# Altium schematic sheet B_Microchip.SchDoc
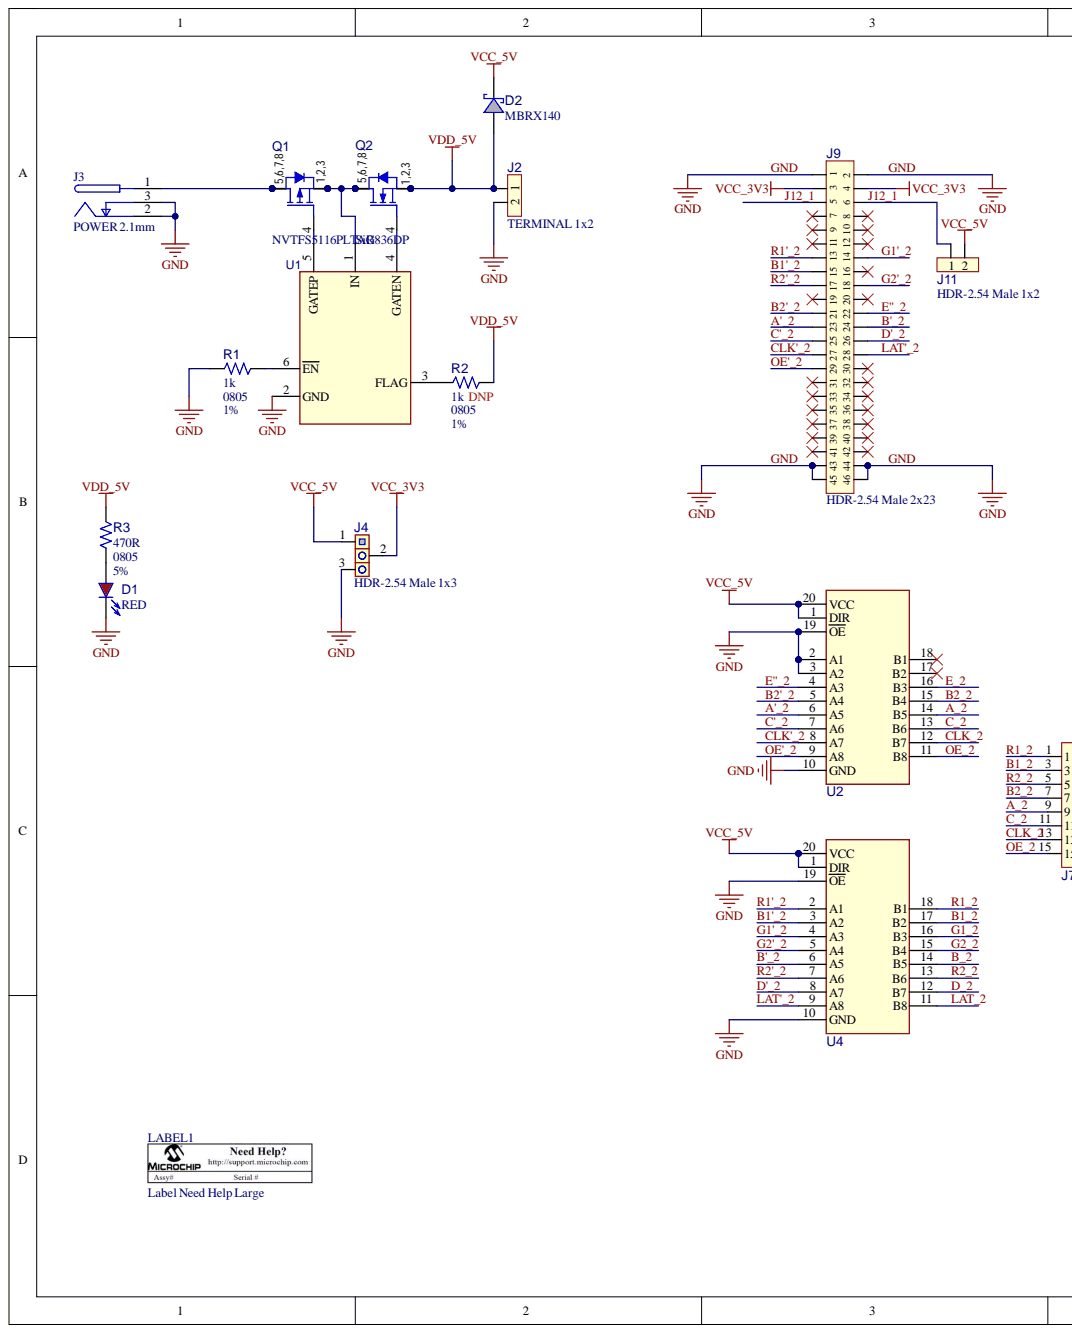
[diagram p0: B_Microchip.SchDoc, left]
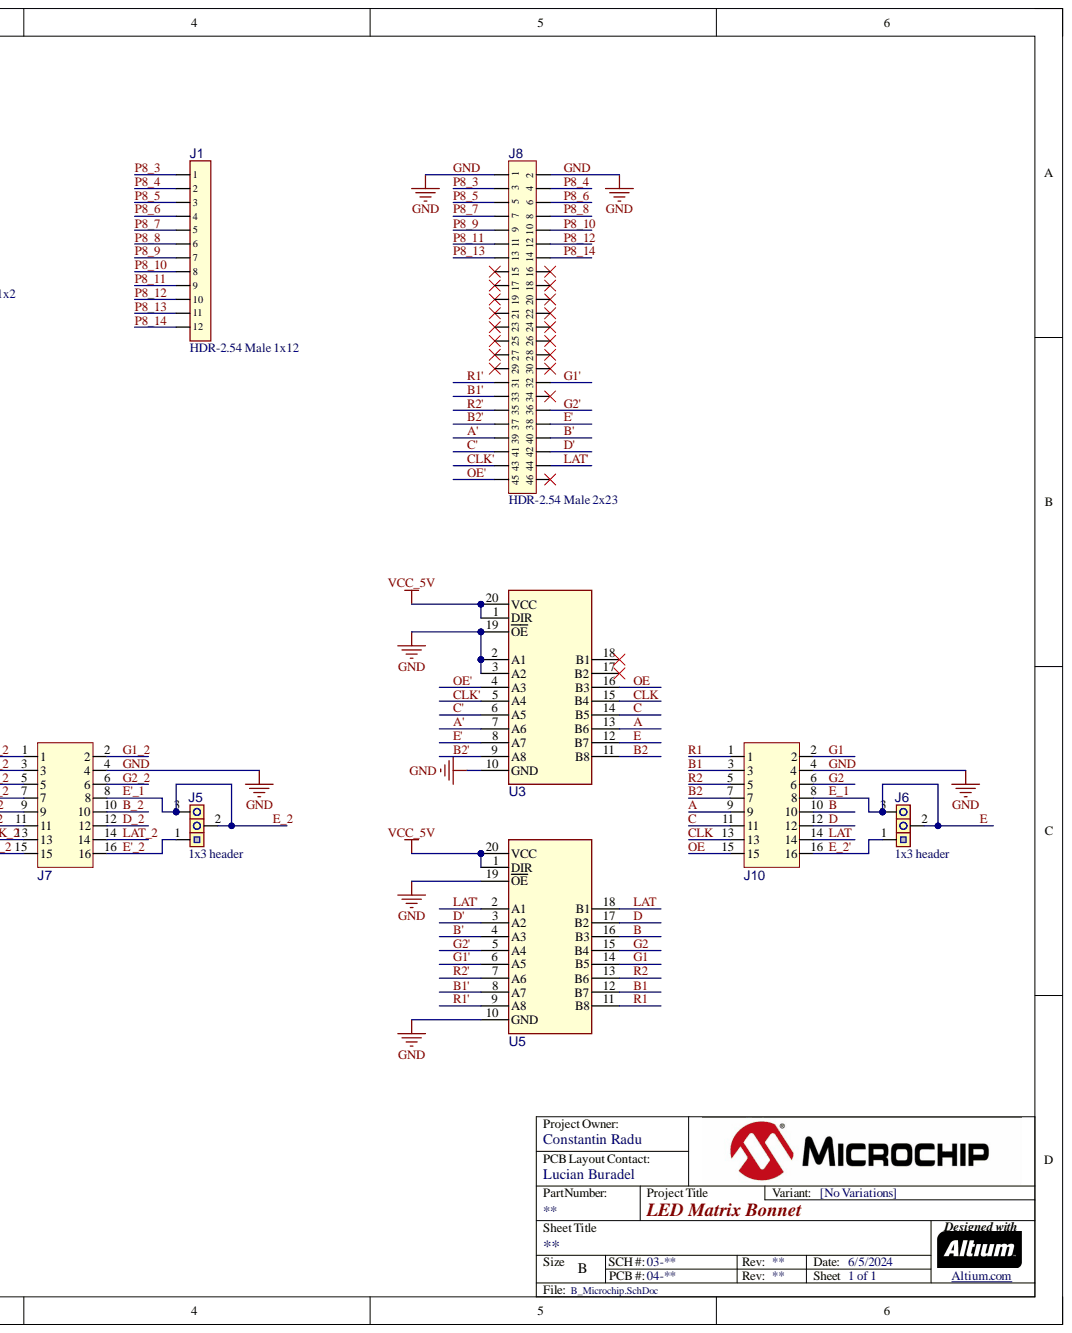
[diagram p1: B_Microchip.SchDoc, right]

## Parts
D1  value RED  Package: 0805  [LED_0805_Red]  (hidden comment: LED_red)
D2  value MBRX140  [SOD-123]  (hidden comment: Diode Schottky)
J1  value HDR-2.54 Male 1x12  [HDR_M_2.54_1x12]  (hidden comment: Header 1x12)
J2  value TERMINAL 1x2  [CON_TERMINAL_5_1x2_TH_RA_PHO_1935161]  (hidden comment: Header 1x2)
J3  value POWER 2.1mm  [CON_JACK_POWER_TH_RA_CUI_PJ-002]  (hidden comment: CON JACK 2C 3P SPST)
J4  value HDR-2.54 Male 1x3  [HDR_M_2.54_1x3]  (hidden comment: Header 1x3)
J5  value 1x3 header  [HDR_M_2.54_1x3]  (hidden comment: Header 1x3)
J6  value 1x3 header  [HDR_M_2.54_1x3]  (hidden comment: Header 1x3)
J7  Header 2x8  [HDR_M_2.54_2X8_S_TH_V_SAM_TSS-108-01-G-D]  (value not drawn)
J8  value HDR-2.54 Male 2x23  [HDR_M_2.54_2x23]  (hidden comment: Header 2x23)
J9  value HDR-2.54 Male 2x23  [HDR_M_2.54_2x23]  (hidden comment: Header 2x23)
J10  Header 2x8  [HDR_M_2.54_2X8_S_TH_V_SAM_TSS-108-01-G-D]  (value not drawn)
J11  value HDR-2.54 Male 1x2  [HDR_M_2.54_1x2]  (hidden comment: Header 1x2)
LABEL1  value Label Need Help Large  Category: Label  Type: Large  [LABEL_Need_Help_Large]  (hidden comment: LABEL_Need_Help_Large)
Q1  value NVTFS5116PLTAG  Manufacturer Part Number 1: NVTFS5116PLTAG  [WDFN-8_3.3x3.3]  (hidden comment: Transistor MOS-P SSSGDDDD)
Q2  value SiR836DP  [DFN3X3A_8L_NEP_P_AOS]  (hidden comment: Transistor MOS-N SSSGDDDD)
R1  value 1k  Package: 0805  Tolerance: 1%  [RES_C_0805]  (hidden comment: Resistor)
R2  value 1k  Package: 0805  Populated: DNP  [RES_C_0805]  (hidden comment: Resistor)
R3  value 470R  Package: 0805  Tolerance: 5%  [RES_C_0805]  (hidden comment: Resistor)
U1  MAX4864 SOT-23-6  [SOT-23-6]  (value not drawn)
U2  74AHCT245 TSSOP-20  [TSSOP-20]  (value not drawn)
U3  74AHCT245 TSSOP-20  [TSSOP-20]  (value not drawn)
U4  74AHCT245 TSSOP-20  [TSSOP-20]  (value not drawn)
U5  74AHCT245 TSSOP-20  [TSSOP-20]  (value not drawn)

## Nets
VCC_3V3: J4.2 J9.3 J9.4
VCC_5V: D2.2 J4.1 J11.2 U2.1(DIR) U2.20(VCC) U3.1(DIR) U3.20(VCC) U4.1(DIR) U4.20(VCC) U5.1(DIR) U5.20(VCC)
VDD_5V: D2.1 J2.1 Q2.1 Q2.2 Q2.3 R2.2 R3.2
A: J10.9 U3.13(B6)
A': J8.39 U3.7(A6)
A'_2: J9.23 U2.6(A5)
A_2: J7.9 U2.14(B5)
B: J10.10 U5.16(B3)
B1: J10.3 U5.12(B7)
B1': J8.33 U5.8(A7)
B1'_2: J9.15 U4.3(A2)
B1_2: J7.3 U4.17(B2)
B2: J10.7 U3.11(B8)
B2': J8.37 U3.9(A8)
B2'_2: J9.21 U2.5(A4)
B2_2: J7.7 U2.15(B4)
B': J8.40 U5.4(A3)
B'_2: J9.24 U4.6(A5)
B_2: J7.10 U4.14(B5)
C: J10.11 U3.14(B5)
C': J8.41 U3.6(A5)
C'_2: J9.25 U2.7(A6)
C_2: J7.11 U2.13(B6)
CLK: J10.13 U3.15(B4)
CLK': J8.43 U3.5(A4)
CLK'_2: J9.27 U2.8(A7)
CLK_2: J7.13 U2.12(B7)
D: J10.12 U5.17(B2)
D': J8.42 U5.3(A2)
D'_2: J9.26 U4.8(A7)
D_2: J7.12 U4.12(B7)
E: J6.2 J6.3 J10.8 U3.12(B7)
E': J8.38 U3.8(A7)
E''_2: J9.22 U2.4(A3)
E'_1: J5.2 J5.3 J7.8 U2.16(B3)
E'_2: J5.1 J7.16
E_2': J6.1 J10.16
G1: J10.2 U5.14(B5)
G1': J8.32 U5.6(A5)
G1'_2: J9.14 U4.4(A3)
G1_2: J7.2 U4.16(B3)
G2: J10.6 U5.15(B4)
G2': J8.36 U5.5(A4)
G2'_2: J9.18 U4.5(A4)
G2_2: J7.6 U4.15(B4)
GND: D1.2 J2.2 J3.2 J3.3 J4.3 J7.4 J8.1 J8.2 J9.1 J9.2 J9.43 J9.44 J9.45 J9.46 J10.4 R1.1 U1.2(GND) U2.2(A1) U2.3(A2) U2.10(GND) U2.19(OE) U3.2(A1) U3.3(A2) U3.10(GND) U3.19(OE) U4.10(GND) U4.19(OE) U5.10(GND) U5.19(OE)
J12_1: J9.5 J9.6 J11.1
LAT: J10.14 U5.18(B1)
LAT': J8.44 U5.2(A1)
LAT'_2: J9.28 U4.9(A8)
LAT_2: J7.14 U4.11(B8)
OE: J10.15 U3.16(B3)
OE': J8.45 U3.4(A3)
OE'_2: J9.29 U2.9(A8)
OE_2: J7.15 U2.11(B8)
P8_3: J1.1 J8.3
P8_4: J1.2 J8.4
P8_5: J1.3 J8.5
P8_6: J1.4 J8.6
P8_7: J1.5 J8.7
P8_8: J1.6 J8.8
P8_9: J1.7 J8.9
P8_10: J1.8 J8.10
P8_11: J1.9 J8.11
P8_12: J1.10 J8.12
P8_13: J1.11 J8.13
P8_14: J1.12 J8.14
R1: J10.1 U5.11(B8)
R1': J8.31 U5.9(A8)
R1'_2: J9.13 U4.2(A1)
R1_2: J7.1 U4.18(B1)
R2: J10.5 U5.13(B6)
R2': J8.35 U5.7(A6)
R2'_2: J9.17 U4.7(A6)
R2_2: J7.5 U4.13(B6)
NetD1_1: D1.1 R3.1
NetJ3_1: J3.1 Q1.5 Q1.6 Q1.7 Q1.8
NetQ1_1: Q1.1 Q1.2 Q1.3 Q2.5 Q2.6 Q2.7 Q2.8 U1.1(IN)
NetQ1_4: Q1.4 U1.5(GATEP)
NetQ2_4: Q2.4 U1.4(GATEN)
NetR1_2: R1.2 U1.6(EN)
NetR2_1: R2.1 U1.3(FLAG)

Not connected: J8 15 16 17 18 19 20 21 22 23 24 25 26 27 28 29 30 34 46; J9 7 8 9 10 11 12 16 19 20 30 31 32 33 34 35 36 37 38 39 40 41 42; U2 17(B2) 18(B1); U3 17(B2) 18(B1)

## Library data of the parts
D1 = DIODE1049: LED; Manufacturer 1: Dialight; Manufacturer Part Number 1: 598-8110-107F; Database Table Name: Diode; Description_: DIO LED RED 2.2V 20mA 40mcd Clear SMD 0805; Dev Tools Status: Production; Forward Current: 20mA; Owner: Tad Ahlvers; Populated: YES; Restricted Sourcing: No; Type: LED Single; Category: Diode; Component Kind: Standard; ComponentLink1Description: SVN Datasheet; ComponentLink1URL: https://chn-vm-apps.microchip.com/mchprepo/parts/Datasheets/598_SelectorGuide.pdf; ComponentLink2Description: Local Datasheet; ComponentLink3Description: Open Symbol; ComponentLink4Description: Open Footprint; ComponentLink5Description: Open Footprint 2; ComponentLink6Description: Open Footprint 3; ComponentLink7Description: Open Footprint 4; Library Name: MCHP-CDB.DbLib; Manufacturer 2: Ledtech; Manufacturer Part Number 2: LT-8A13-43-UR93-T4; MCHP-ID: DIODE1049; Quantity Override: 1; Revision: 2; Status: MCL Design; Supplier 1: Digi-Key; Supplier 2: Ledtech; Supplier Part Number 1: 350-2038-1-ND; Supplier Part Number 2: LT-8A13-43-UR93-T4; Symbol: LED_red; ComponentLink2URL: C:\ALTIUM_WORK\00001_MCHP-CDB\Datasheets\598_SelectorGuide.pdf; ComponentLink3URL: C:\ALTIUM_WORK\00001_MCHP-CDB\Library\LibraryRefs\LED_red.SchLib; ComponentLink4URL: C:\ALTIUM_WORK\00001_MCHP-CDB\Library\Footprints\LED_0805_Red.PcbLib
D2 = DIODE1174: Manufacturer 1: Diodes Incorporated; Manufacturer Part Number 1: B140HW-7; Description_: DIO SCTKY MBRX140-TP 550mV 1A 40V SMD SOD-123; Dev Tools Status: Production; Forward Current: 1A; Populated: YES; Restricted Sourcing: No; Reverse Current: 300uA; Reverse Recovery Time: <500ns; Reverse Voltage: 40V; Type: Schottky Single; Category: Diode; Component Kind: Standard; ComponentLink1Description: SVN Datasheet; ComponentLink1URL: https://chn-vm-apps.microchip.com/mchprepo/parts/Datasheets/MBRX120-MBRX160(SOD123).pdf; ComponentLink2Description: Local Datasheet; ComponentLink3Description: Open Symbol; ComponentLink4Description: Open Footprint; ComponentLink5Description: Open Footprint 2; ComponentLink6Description: Open Footprint 3; ComponentLink7Description: Open Footprint 4; Database Table Name: Diode; Library Name: MCHP-CDB.DbLib; Manufacturer 2: Micro Commercial Co; Manufacturer 3: SMC Diode Solutions; Manufacturer Part Number 2: MBRX140-TP; Manufacturer Part Number 3: MBR140HWTR; MCHP-ID: DIODE1174; Operating Temperature: -55C to +125C; Package: SOD-123; Quantity Override: 1; Revision: 2; Status: MCL Design; Supplier 1: Digi-Key; Supplier 2: Digi-Key; Supplier 3: Digi-Key; Supplier Part Number 1: B140HWDICT-ND; Supplier Part Number 2: MBRX140TPMSCT-ND; Supplier Part Number 3: MBR140HWTRSMC-ND; Symbol: Diode Schottky; ComponentLink2URL: C:\ALTIUM_WORK\00001_MCHP-CDB\Datasheets\MBRX120-MBRX160(SOD123).pdf; ComponentLink3URL: C:\ALTIUM_WORK\00001_MCHP-CDB\Library\LibraryRefs\Diode Schottky.SchLib; ComponentLink4URL: C:\ALTIUM_WORK\00001_MCHP-CDB\Library\Footprints\SOD-123.PcbLib
J1 = CON0155: Manufacturer 1: Samtec; Manufacturer Part Number 1: TSW-112-07-G-S; Database Table Name: Connector; Description_: CON HDR-2.54 Male 1x12 Gold 5.84MH TH VERT; Dev Tools Status: Production; Owner: Randolph Sobrepena; Populated: YES; Restricted Sourcing: No; Category: Connector; Component Kind: Standard; ComponentLink1Description: SVN Datasheet; ComponentLink1URL: https://chn-vm-apps.microchip.com/mchprepo/parts/Datasheets/TSW-XXX-XX-XXX-X-XX-XXX-MKT.pdf; ComponentLink2Description: Local Datasheet; ComponentLink3Description: Open Symbol; ComponentLink4Description: Open Footprint; ComponentLink5Description: Open Footprint 2; ComponentLink6Description: Open Footprint 3; ComponentLink7Description: Open Footprint 4; Library Name: MCHP-CDB.DbLib; Manufacturer 2: Samtec; Manufacturer Part Number 2: TSW-112-07-S-S; MCHP-ID: CON0155; Quantity Override: 1; Revision: 1; Status: MCL Design; Supplier 1: Samtec; Supplier 2: Samtec; Supplier Part Number 1: TSW-112-07-G-S; Supplier Part Number 2: TSW-112-07-S-S; Symbol: Header 1x12; Type: Header 2.54mm; ComponentLink2URL: C:\ALTIUM_WORK\00001_MCHP-CDB\Datasheets\TSW-XXX-XX-XXX-X-XX-XXX-MKT.pdf; ComponentLink3URL: C:\ALTIUM_WORK\00001_MCHP-CDB\Library\LibraryRefs\Header 1x12.SchLib; ComponentLink4URL: C:\ALTIUM_WORK\00001_MCHP-CDB\Library\Footprints\HDR_M_2.54_1x12.PcbLib
J2 = CON0287: Manufacturer 1: Phoenix Contact; Manufacturer Part Number 1: 1935161; Current: 18A; Database Table Name: Connector; Description_: CON TERMINAL 5mm 1x2 Female 12-26AWG 18A TH R/A; Dev Tools Status: Production; Owner: Stephen Humberd; Populated: YES; Restricted Sourcing: No; Voltage: 300V; Category: Connector; Component Kind: Standard; ComponentLink1Description: SVN Datasheet; ComponentLink1URL: https://chn-vm-apps.microchip.com/mchprepo/parts/Datasheets/1935161.pdf; ComponentLink2Description: Local Datasheet; ComponentLink3Description: Open Symbol; ComponentLink4Description: Open Footprint; ComponentLink5Description: Open Footprint 2; ComponentLink6Description: Open Footprint 3; ComponentLink7Description: Open Footprint 4; Manufacturer 2: Degson Electronics Co., Ltd.; Manufacturer 3: Degson Electronics Co., Ltd.; Manufacturer Part Number 2: DG300-5.0-02P-12-00A(H); Manufacturer Part Number 3: DG127-5.0-02P-14-00A(H); MCHP-ID: CON0287; Quantity Override: 1; Revision: 2; Status: MCL Design; Supplier 1: Digi-Key; Supplier 2: Degson Electronics Co., Ltd.; Supplier 3: Degson Electronics Co., Ltd.; Supplier Part Number 1: 277-1667-ND; Supplier Part Number 2: DG300-5.0-02P-12-00A(H); Supplier Part Number 3: DG127-5.0-02P-14-00A(H); Symbol: Header 1x2; Type: Terminal Block; ComponentLink2URL: C:\ALTIUM_WORK\00001_MCHP-CDB\Datasheets\1935161.pdf; ComponentLink3URL: C:\ALTIUM_WORK\00001_MCHP-CDB\Library\LibraryRefs\Header 1x2.SchLib; ComponentLink4URL: C:\ALTIUM_WORK\00001_MCHP-CDB\Library\Footprints\CON_TERMINAL_5_1x2_TH_RA_PHO_1935161.PcbLib; Library Name: MCL-Offline.DbLib
J3 = CON1634: Manufacturer 1: RS Pro; Manufacturer Part Number 1: 448-382; Current: 1A; Database Table Name: Connector; Description_: CON POWER 2.1mm 5.5mm SWITCH TH R/A; Dev Tools Status: Production; Owner: Cristian Papuc; Populated: YES; Restricted Sourcing: No; Voltage: 12V; Assembly Type: TH; Category: Connector; Component Kind: Standard; ComponentLink1Description: SVN Datasheet; ComponentLink1URL: https://chn-vm-apps.microchip.com/mchprepo/parts/Datasheets/0900766b81582448.pdf; ComponentLink2Description: Local Datasheet; ComponentLink3Description: Open Symbol; ComponentLink4Description: Open Footprint; ComponentLink5Description: Open Footprint 2; ComponentLink6Description: Open Footprint 3; ComponentLink7Description: Open Footprint 4; MCHP-ID: CON1634; Quantity Override: 1; Revision: 2; Status: MCL Design; Supplier 1: RS Pro; Supplier Part Number 1: 448-382; Symbol: CON JACK 2C 3P SPST; Type: Power; ComponentLink2URL: C:\ALTIUM_WORK\00001_MCHP-CDB\Datasheets\0900766b81582448.pdf; ComponentLink3URL: C:\ALTIUM_WORK\00001_MCHP-CDB\Library\LibraryRefs\CON JACK 2C 3P SPST.SchLib; ComponentLink4URL: C:\ALTIUM_WORK\00001_MCHP-CDB\Library\Footprints\CON_JACK_POWER_TH_RA_CUI_PJ-002.PcbLib; Library Name: MCL-Offline.DbLib
J4 = CON1105: Manufacturer 1: FCI; Manufacturer Part Number 1: 68000-103HLF; Database Table Name: Connector; Description_: CON HDR-2.54 Male 1x3 Gold 5.84MH TH VERT; Dev Tools Status: Production; Owner: Bogdan P (M91398); Populated: Yes; Restricted Sourcing: No; Category: Connector; Component Kind: Standard; ComponentLink1Description: SVN Datasheet; ComponentLink1URL: https://chn-vm-apps.microchip.com/mchprepo/parts/Datasheets/68000.pdf; ComponentLink2Description: Local Datasheet; ComponentLink3Description: Open Symbol; ComponentLink4Description: Open Footprint; ComponentLink5Description: Open Footprint 2; ComponentLink6Description: Open Footprint 3; ComponentLink7Description: Open Footprint 4; Manufacturer 2: SHENZHEN JUNHAO ENGINEERING; Manufacturer 3: Shanghai NS-TECH; Manufacturer Part Number 2: R-211-0311-0021-000; Manufacturer Part Number 3: NS-201-SH0100-1*3; MCHP-ID: CON1105; Quantity Override: 1; Revision: 5; Status: MCL Design; Supplier 1: Digi-Key; Supplier 2: SHENZHEN JUNHAO ENGINEERING; Supplier 3: Shanghai NS-TECH; Supplier Part Number 1: 609-3461-ND; Supplier Part Number 2: R-211-0311-0021-000; Supplier Part Number 3: NS-201-SH0100-1*3; Symbol: Header 1x3; Type: Header 2.54mm; ComponentLink2URL: C:\ALTIUM_WORK\00001_MCHP-CDB\Datasheets\68000.pdf; ComponentLink3URL: C:\ALTIUM_WORK\00001_MCHP-CDB\Library\LibraryRefs\Header 1x3.SchLib; ComponentLink4URL: C:\ALTIUM_WORK\00001_MCHP-CDB\Library\Footprints\HDR_M_2.54_1x3.PcbLib; Library Name: MCL-Offline.DbLib
J5 J6 = CON2491: Manufacturer 1: METZ CONNECT USA Inc.; Manufacturer Part Number 1: PR20203VBNN; Current: 3A; Database Table Name: Connector; Description_: CON HDR-2.54 Male 1x3 Gold 6.10MH TH VERT; Dev Tools Status: Production; Owner: Dragos Danaila; Populated: YES; Restricted Sourcing: No; Assembly Type: TH; Category: Connector; Component Kind: Standard; ComponentLink1Description: SVN Datasheet; ComponentLink1URL: https://chn-vm-apps.microchip.com/mchprepo/parts/Datasheets/Data_sheet_PR202XXVBNN.PDF; ComponentLink2Description: Local Datasheet; ComponentLink3Description: Open Symbol; ComponentLink4Description: Open Footprint; ComponentLink5Description: Open Footprint 2; ComponentLink6Description: Open Footprint 3; ComponentLink7Description: Open Footprint 4; MCHP-ID: CON2491; Operating Temperature: -40C to +105C; Quantity Override: 1; Revision: 2; Status: MCL Design; Supplier 1: Digi-Key; Supplier Part Number 1: Deprecated_1849-1003-ND; Symbol: Header 1x3; Type: Header 2.54mm; ComponentLink2URL: C:\ALTIUM_WORK\00001_MCHP-CDB\Datasheets\Data_sheet_PR202XXVBNN.PDF; ComponentLink3URL: C:\ALTIUM_WORK\00001_MCHP-CDB\Library\LibraryRefs\Header 1x3.SchLib; ComponentLink4URL: C:\ALTIUM_WORK\00001_MCHP-CDB\Library\Footprints\HDR_M_2.54_1x3.PcbLib; Library Name: MCL-Offline.DbLib
J8 J9 = CON1946: Manufacturer 1: Samtec Inc.; Manufacturer Part Number 1: TSW-123-07-F-D; Database Table Name: Connector; Description_: CON HDR-2.54 Male 2x23 Gold 5.84MH TH VERT; Dev Tools Status: Design; Owner: Manikandan Balakrishnan; Populated: YES; Restricted Sourcing: No; Assembly Type: TH; Category: Connector; Component Kind: Standard; ComponentLink1Description: SVN Datasheet; ComponentLink1URL: https://chn-vm-apps.microchip.com/mchprepo/parts/Datasheets/TSW-XXX-XX-XXX-X-XX-XXX-MKT.pdf; ComponentLink2Description: Local Datasheet; ComponentLink3Description: Open Symbol; ComponentLink4Description: Open Footprint; ComponentLink5Description: Open Footprint 2; ComponentLink6Description: Open Footprint 3; ComponentLink7Description: Open Footprint 4; MCHP-ID: CON1946; Quantity Override: 1; Revision: B; Status: MCL Design; Supplier 1: Digi-Key; Supplier Part Number 1: SAM12366-ND; Symbol: Header 2x23; Type: Header 2.54mm; ComponentLink2URL: C:\ALTIUM_WORK\00001_MCHP-CDB\Datasheets\TSW-XXX-XX-XXX-X-XX-XXX-MKT.pdf; ComponentLink3URL: C:\ALTIUM_WORK\00001_MCHP-CDB\Library\LibraryRefs\Header 2x23.SchLib; ComponentLink4URL: C:\ALTIUM_WORK\00001_MCHP-CDB\Library\Footprints\HDR_M_2.54_2x23.PcbLib; Library Name: MCL-Offline.DbLib
J11 = CON1108: Manufacturer 1: FCI; Manufacturer 10: Shanghai NS-TECH; Manufacturer Part Number 1: 68000-202HLF; Manufacturer Part Number 10: NS-201-SH0100-1*2; Database Table Name: Connector; Description_: CON HDR-2.54 Male 1x2 Gold 5.84MH TH VERT; Dev Tools Status: Production; Owner: Bogdan P (M91398); Populated: Yes; Restricted Sourcing: No; Category: Connector; Component Kind: Standard; ComponentLink1Description: SVN Datasheet; ComponentLink1URL: https://chn-vm-apps.microchip.com/mchprepo/parts/Datasheets/77311.pdf; ComponentLink2Description: Local Datasheet; ComponentLink3Description: Open Symbol; ComponentLink4Description: Open Footprint; ComponentLink5Description: Open Footprint 2; ComponentLink6Description: Open Footprint 3; ComponentLink7Description: Open Footprint 4; Library Name: MCHP-CDB.DbLib; Manufacturer 2: FCI; Manufacturer 3: FCI; Manufacturer 4: Samtec; Manufacturer 5: Sullins; Manufacturer 6: Würth Elektronik; Manufacturer 7: SPC Technology; Manufacturer 8: Samtec; Manufacturer 9: Samtec; Manufacturer Part Number 2: 77311-118-02LF; Manufacturer Part Number 3: 68001-202HLF; Manufacturer Part Number 4: TSW-102-07-G-S; Manufacturer Part Number 5: PBC02SAAN; Manufacturer Part Number 6: 61300211121; Manufacturer Part Number 7: SPC20481; Manufacturer Part Number 8: TSW-102-07-F-S; Manufacturer Part Number 9: TSW-102-07-S-S; MCHP-ID: CON1108; Quantity Override: 1; Revision: 8; Status: MCL Design; Supplier 1: Digi-Key; Supplier 2: Digi-Key; Supplier 3: Digi-Key; Supplier 4: Digi-Key; Supplier 5: Digi-Key; Supplier 6: Digi-Key; Supplier 7: Newark; Supplier 8: Newark; Supplier 9: Samtec; Supplier 10: Shanghai NS-TECH; Supplier Part Number 1: 609-3502-ND; Supplier Part Number 2: 609-4434-ND; Supplier Part Number 3: 609-3469-ND; Supplier Part Number 4: SAM1029-02-ND; Supplier Part Number 5: S1011E-02-ND; Supplier Part Number 6: 732-5315-ND; Supplier Part Number 7: 93K5734; Supplier Part Number 8: 79P3146; Supplier Part Number 9: TSW-102-07-S-S; Supplier Part Number 10: NS-201-SH0100-1*2; Symbol: Header 1x2; Type: Header 2.54mm; ComponentLink2URL: C:\ALTIUM_WORK\00001_MCHP-CDB\Datasheets\77311.pdf; ComponentLink3URL: C:\ALTIUM_WORK\00001_MCHP-CDB\Library\LibraryRefs\Header 1x2.SchLib; ComponentLink4URL: C:\ALTIUM_WORK\00001_MCHP-CDB\Library\Footprints\HDR_M_2.54_1x2.PcbLib
LABEL1 = 08-00126: Database Table Name: MCHP-Manufacturing; Description_: LABEL, NEED HELP WITH ASSY/SERIAL; Dev Tools Status: Production; Populated: MECH; Component Kind: Standard; ComponentLink1Description: SVN Datasheet; ComponentLink1URL: https://chn-vm-apps.microchip.com/mchprepo/parts/Datasheets/08-00126R6.pdf; ComponentLink2Description: Local Datasheet; ComponentLink3Description: Open Symbol; ComponentLink4Description: Open Footprint; ComponentLink5Description: Open Footprint 2; ComponentLink6Description: Open Footprint 3; ComponentLink7Description: Open Footprint 4; Library Name: MCHP-CDB.DbLib; MCHP-ID: 08-00126; Quantity Override: 1; Revision: 6; Status: MCL Design; Symbol: LABEL_Need_Help_Large; ComponentLink2URL: C:\ALTIUM_WORK\00001_MCHP-CDB\Datasheets\08-00126R6.pdf; ComponentLink3URL: C:\ALTIUM_WORK\00001_MCHP-CDB\Library\LibraryRefs\LABEL_Need_Help_Large.SchLib; ComponentLink4URL: C:\ALTIUM_WORK\00001_MCHP-CDB\Library\Footprints\LABEL_Need_Help_Large.PcbLib
Q1 = TRA1273: Transistor MOS; Manufacturer 1: ON Semiconductor; Current: -6A; Description_: TRANS FET P-CH NVTFS5116PLTAG -60V -6A 3.2W WDFN8 AEC−Q101; Dev Tools Status: Design; Drain to Source Voltage: -60V; Gate to Source Voltage: ±20V; Owner: Cristian Papuc; Populated: YES; Power: 3.2W; Restricted Sourcing: No; Type: FET; Category: Transistor; Component Kind: Standard; ComponentLink1Description: SVN Datasheet; ComponentLink1URL: https://chn-vm-apps.microchip.com/mchprepo/parts/Datasheets/NVTFS5116PL-D.pdf; ComponentLink2Description: Local Datasheet; ComponentLink3Description: Open Symbol; ComponentLink4Description: Open Footprint; ComponentLink5Description: Open Footprint 2; ComponentLink6Description: Open Footprint 3; ComponentLink7Description: Open Footprint 4; Database Table Name: Transistor; MCHP-ID: TRA1273; Operating Temperature: -55C to +175C; Package: WDFN-8_3.3x3.3; Quantity Override: 1; Revision: A; Status: MCL Design; Supplier 1: Farnell; Supplier Part Number 1: 2533214; Symbol: Transistor MOS-P SSSGDDDD; Voltage: -60V; ComponentLink2URL: C:\ALTIUM_WORK\00001_MCHP-CDB\Datasheets\NVTFS5116PL-D.pdf; ComponentLink3URL: C:\ALTIUM_WORK\00001_MCHP-CDB\Library\LibraryRefs\Transistor MOS-P SSSGDDDD.SchLib; ComponentLink4URL: C:\ALTIUM_WORK\00001_MCHP-CDB\Library\Footprints\WDFN-8_3.3x3.3.PcbLib; Library Name: MCL-Offline.DbLib
Q2 = TRA1271: Manufacturer 1: Vishay; Manufacturer Part Number 1: SIR836DP-T1-GE3; Current: 21A; Description_: TRANS FET N-CH SiR836DP 40V 21A 15.6W PPAK SO-8; Dev Tools Status: Production; Drain to Source Voltage: 40V; Gate to Source Voltage: ±20V; Owner: Cristian Papuc; Populated: YES; Power: 15.6W; Restricted Sourcing: No; Type: FET; Category: Transistor; Component Kind: Standard; ComponentLink1Description: SVN Datasheet; ComponentLink1URL: https://chn-vm-apps.microchip.com/mchprepo/parts/Datasheets/sir836dp.pdf; ComponentLink2Description: Local Datasheet; ComponentLink3Description: Open Symbol; ComponentLink4Description: Open Footprint; ComponentLink5Description: Open Footprint 2; ComponentLink6Description: Open Footprint 3; ComponentLink7Description: Open Footprint 4; Database Table Name: Transistor; MCHP-ID: TRA1271; Operating Temperature: -55C to +150C; Package: PPAK SO-8; Quantity Override: 1; Revision: 2; Status: MCL Design; Supplier 1: Digi-Key; Supplier Part Number 1: SIR836DP-T1-GE3CT-ND; Symbol: Transistor MOS-N SSSGDDDD; Voltage: 40V; ComponentLink2URL: C:\ALTIUM_WORK\00001_MCHP-CDB\Datasheets\sir836dp.pdf; ComponentLink3URL: C:\ALTIUM_WORK\00001_MCHP-CDB\Library\LibraryRefs\Transistor MOS-N SSSGDDDD.SchLib; ComponentLink4URL: C:\ALTIUM_WORK\00001_MCHP-CDB\Library\Footprints\Power56-8_5x6.PcbLib; Library Name: MCL-Offline.DbLib
R1 = RES1221: Manufacturer 1: Vishay; Manufacturer Part Number 1: CRCW08051K00FKEA; Description_: RES TKF 1k 1% 1/8W SMD 0805; Dev Tools Status: Production; Owner: Mihai T (M91442); Populated: Yes; Power: 1/8W; Temperature Coefficient: 100ppm/C; Voltage: 150V; Category: Resistor; Component Kind: Standard; ComponentLink1Description: SVN Datasheet; ComponentLink1URL: https://chn-vm-apps.microchip.com/mchprepo/parts/Datasheets/dcrcwe3.pdf; ComponentLink2Description: Local Datasheet; ComponentLink3Description: Open Symbol; ComponentLink4Description: Open Footprint; ComponentLink5Description: Open Footprint 2; ComponentLink6Description: Open Footprint 3; ComponentLink7Description: Open Footprint 4; Composition: TKF; Database Table Name: Resistor; Description: Resistor; MCHP-ID: RES1221; Operating Temperature: -55C to +155C; Quantity Override: 1; Revision: 0; Status: MCL Design; Supplier 1: Digi-Key; Supplier 2: Farnell; Supplier Part Number 1: 541-1.00KCCT-ND; Supplier Part Number 2: 1469847RL; Symbol: Resistor; Type: RES SMD; ComponentLink2URL: C:\ALTIUM_WORK\00001_MCHP-CDB\Datasheets\dcrcwe3.pdf; ComponentLink3URL: C:\ALTIUM_WORK\00001_MCHP-CDB\Library\LibraryRefs\Resistor.SchLib; ComponentLink4URL: C:\ALTIUM_WORK\00001_MCHP-CDB\Library\Footprints\RES_C_0805.PcbLib; Library Name: MCL-Offline.DbLib
R2 = RES1221: Manufacturer 1: Vishay; Manufacturer Part Number 1: CRCW08051K00FKEA; Description_: RES TKF 1k 1% 1/8W SMD 0805; Dev Tools Status: Production; Owner: Mihai T (M91442); Power: 1/8W; Temperature Coefficient: 100ppm/C; Tolerance: 1%; Voltage: 150V; Category: Resistor; Component Kind: Standard; ComponentLink1Description: SVN Datasheet; ComponentLink1URL: https://chn-vm-apps.microchip.com/mchprepo/parts/Datasheets/dcrcwe3.pdf; ComponentLink2Description: Local Datasheet; ComponentLink3Description: Open Symbol; ComponentLink4Description: Open Footprint; ComponentLink5Description: Open Footprint 2; ComponentLink6Description: Open Footprint 3; ComponentLink7Description: Open Footprint 4; Composition: TKF; Database Table Name: Resistor; Description: Resistor; MCHP-ID: RES1221; Operating Temperature: -55C to +155C; Quantity Override: 1; Revision: 0; Status: MCL Design; Supplier 1: Digi-Key; Supplier 2: Farnell; Supplier Part Number 1: 541-1.00KCCT-ND; Supplier Part Number 2: 1469847RL; Symbol: Resistor; Type: RES SMD; ComponentLink2URL: C:\ALTIUM_WORK\00001_MCHP-CDB\Datasheets\dcrcwe3.pdf; ComponentLink3URL: C:\ALTIUM_WORK\00001_MCHP-CDB\Library\LibraryRefs\Resistor.SchLib; ComponentLink4URL: C:\ALTIUM_WORK\00001_MCHP-CDB\Library\Footprints\RES_C_0805.PcbLib; Library Name: MCL-Offline.DbLib
R3 = RSMT0097: Manufacturer 1: Panasonic; Manufacturer Part Number 1: ERJ-6GEYJ471V; Description_: RES TKF 470R 5% 1/8W SMD 0805; Dev Tools Status: Production; Populated: Yes; Power: 1/8W; Temperature Coefficient: 100ppm/C; Voltage: 150V; Category: Resistor; Component Kind: Standard; ComponentLink1Description: SVN Datasheet; ComponentLink1URL: https://chn-vm-apps.microchip.com/mchprepo/parts/Datasheets/PYu-RC0805_51_RoHS_L_4.pdf; ComponentLink2Description: Local Datasheet; ComponentLink3Description: Open Symbol; ComponentLink4Description: Open Footprint; ComponentLink5Description: Open Footprint 2; ComponentLink6Description: Open Footprint 3; ComponentLink7Description: Open Footprint 4; Composition: TKF; Database Table Name: Resistor; Description: Resistor; Library Name: MCHP-CDB.DbLib; Manufacturer 2: Yageo; Manufacturer 3: KOA Speer; Manufacturer 4: Yageo; Manufacturer Part Number 2: RC0805JR-07470RL; Manufacturer Part Number 3: RK73B2ATTD471J; Manufacturer Part Number 4: 9C08052A4700JLPFT; MCHP-ID: RSMT0097; Operating Temperature: -55C to +155C; Quantity Override: 1; Revision: 2; Status: MCL Design; Supplier 1: Digi-Key; Supplier 2: Digi-Key; Supplier 3: Digi-Key; Supplier 4: KOA Speer; Supplier 5: Yageo; Supplier Part Number 1: P470ACT-ND; Supplier Part Number 2: P470ATR-ND; Supplier Part Number 3: 311-470ARTR-ND; Supplier Part Number 4: RK73B2ATTD471J; Supplier Part Number 5: 9C08052A4700JLPFT; Symbol: Resistor; Type: RES SMD; ComponentLink2URL: C:\ALTIUM_WORK\00001_MCHP-CDB\Datasheets\PYu-RC0805_51_RoHS_L_4.pdf; ComponentLink3URL: C:\ALTIUM_WORK\00001_MCHP-CDB\Library\LibraryRefs\Resistor.SchLib; ComponentLink4URL: C:\ALTIUM_WORK\00001_MCHP-CDB\Library\Footprints\RES_C_0805.PcbLib
J7 J10 = Header 2x8: Component Kind: Standard; Library Name: Header 2x8.Schlib
U1 = MAX4864 SOT-23-6: Component Kind: Standard; Library Name: MAX4864 SOT-23-6.Schlib
U2-U5 = 74AHCT245 TSSOP-20: Component Kind: Standard; ComponentLink1Description: SVN Datasheet; ComponentLink1URL: https://chn-vm-apps.microchip.com/mchprepo/parts/Datasheets/sn74ahc245.pdf; ComponentLink2Description: Local Datasheet; ComponentLink3Description: Open Symbol; ComponentLink4Description: Open Footprint; ComponentLink5Description: Open Footprint 2; ComponentLink6Description: Open Footprint 3; ComponentLink7Description: Open Footprint 4; Library Name: 74AHCT245 TSSOP-20.Schlib; ComponentLink2URL: C:\ALTIUM_WORK\00001_MCHP-CDB\Datasheets\sn74ahc245.pdf; ComponentLink3URL: C:\ALTIUM_WORK\00001_MCHP-CDB\Library\LibraryRefs\74XXX245 DIP-20_SOIC-20_SSOP-20_TSSOP-20.SchLib; ComponentLink4URL: C:\ALTIUM_WORK\00001_MCHP-CDB\Library\Footprints\TSSOP-20.PcbLib
